# Altium schematic sheet [1]-PWR_12VIN.SchDoc([1]-PWR_12VIN)
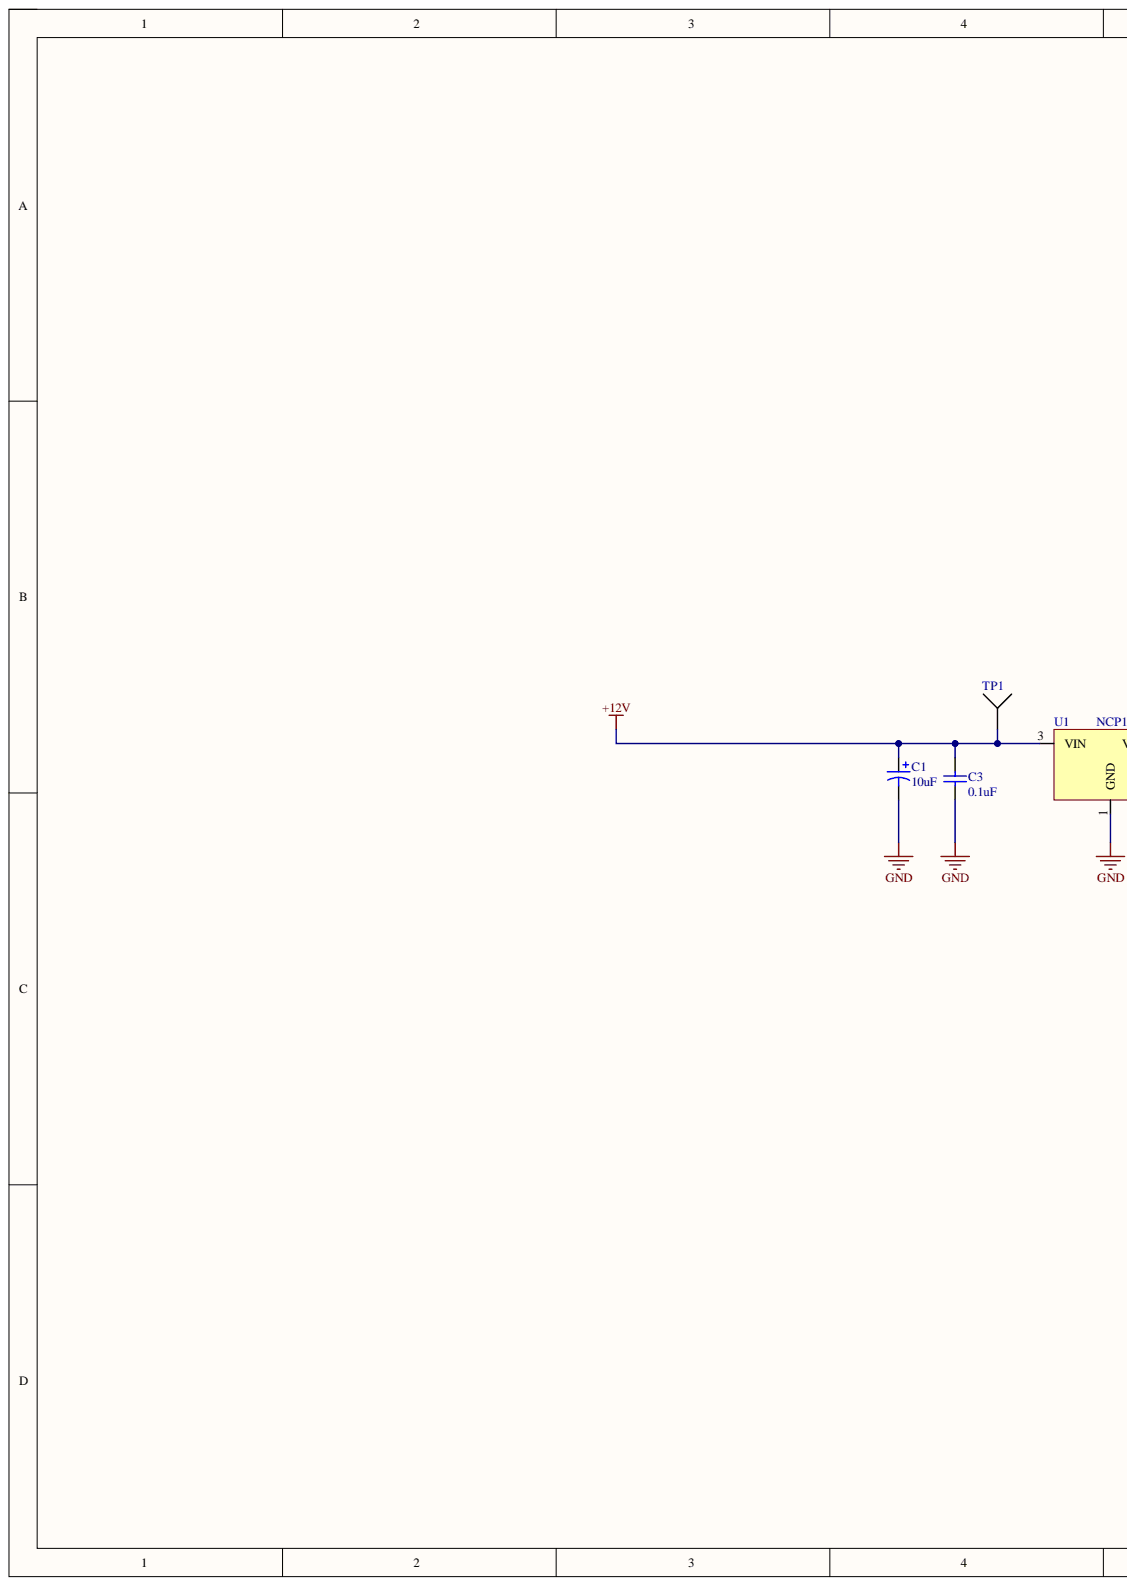
[diagram p0: [1]-PWR_12VIN.SchDoc, left]
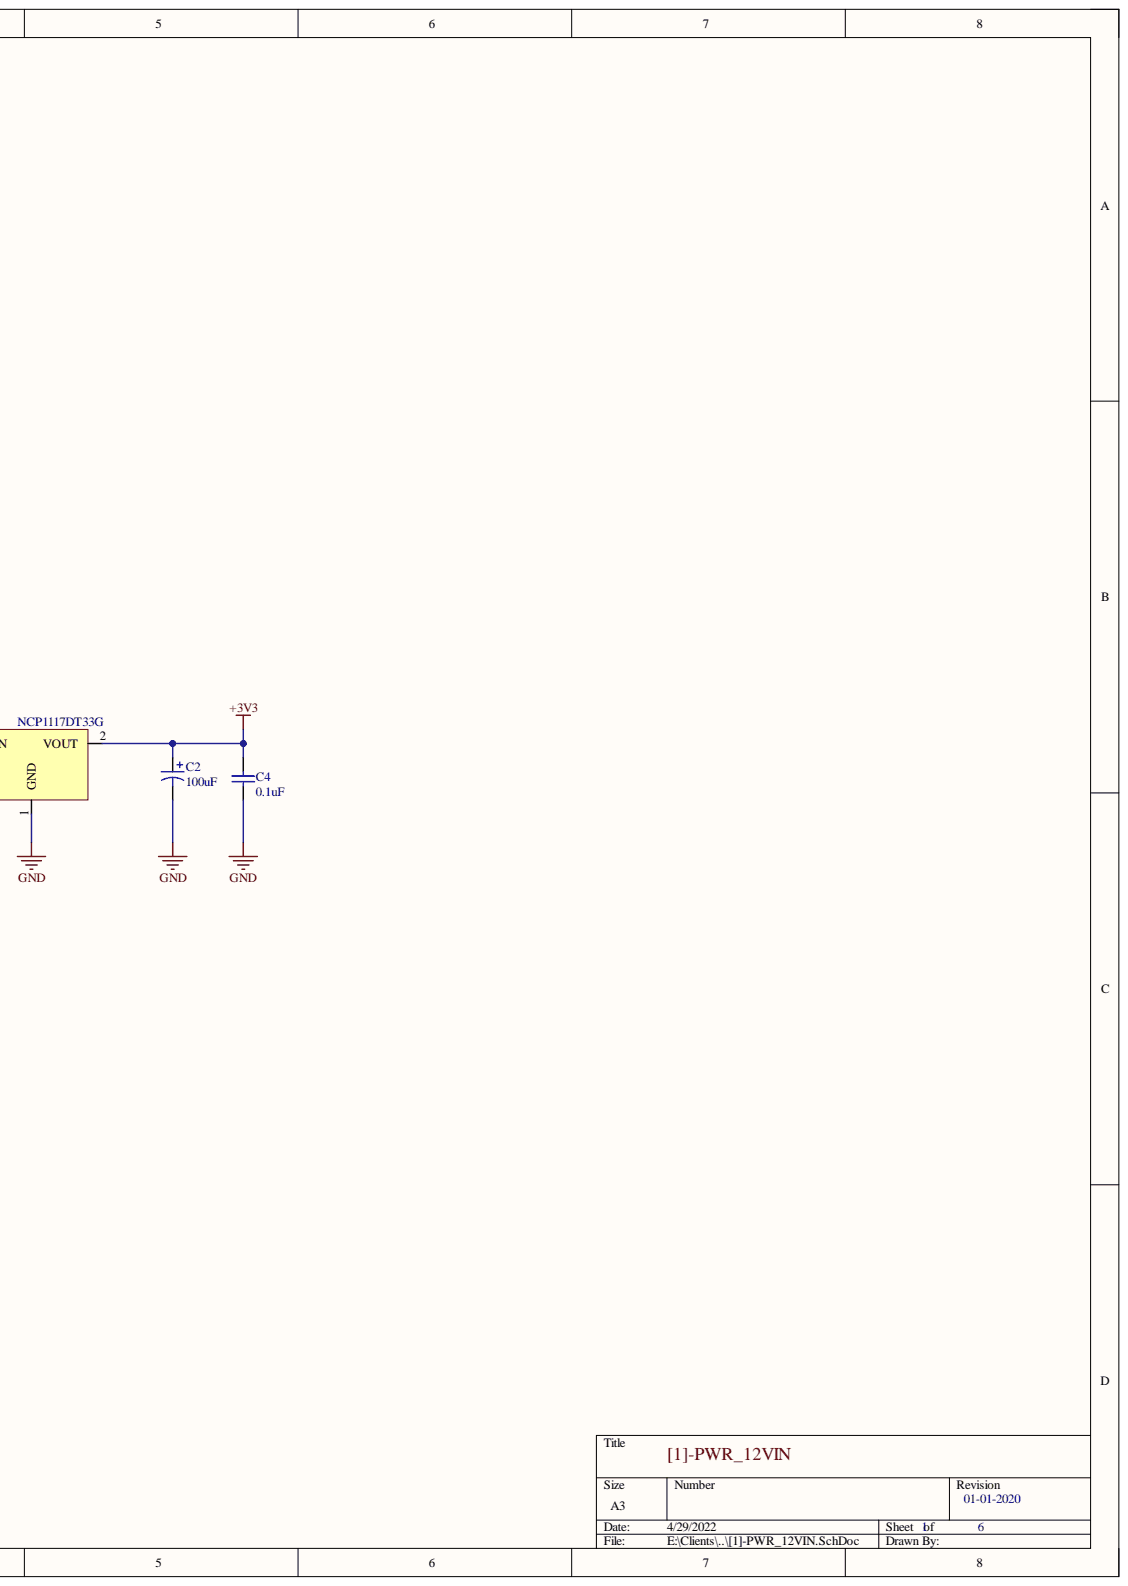
[diagram p1: [1]-PWR_12VIN.SchDoc, right]

## Parts
C1  10uF  [CAP_EEE_C-L]
C2  100uF  [CAPAE6553X55M]
C3  0.1uF  [CAPC1608N]
C4  0.1uF  [CAPC1608N]
TP1  TP_1_MM  [TP_1_MM]  (value not drawn)  (no BOM)
U1  NCP1117DT33G  [DPAK-L]

## Nets
+3V3: C2.1 C4.2 U1.2
+12V: C1.2 C3.2 TP1.1 U1.3
GND: C1.1 C2.2 C3.1 C4.1 U1.1

## Library data of the parts
C1 = EEE-1EA100SR: CAP ALUM 10UF 20% 25V SMD; Manufacturer 1: Panasonic; Lead Length: 2.2mm; Leakage Current: 3uA; Life (Hours): 2000Hour; Ripple Current: 28mA; Capacitance: 10uF; Case/Package: Radial; Component Kind: Standard; Contact Plating: Tin; Diameter: 5mm; Height: 5.4mm; Height - Seated (Max): 0.213inch; Lead Pitch: 1.5mm; Length: 5.5mm; Manufacturer Part Number 1: EEE-1EA100SR; Max Operating Temperature: 85°C; Min Operating Temperature: -40°C; Mount: PCB,Surface Mount; Number of Pins: 2; Package Quantity: 1; Packaging: Tape and Reel; Pricing 1: 1=0.31, 10=0.214, 100=0.1286, 500=0.09646 (USD); Radiation Hardening: No; REACH SVHC: No SVHC; Ripple Current (AC): 28mA; Stock 1: 6724; Supplier 1: Digi-Key; Supplier Part Number 1: PCE3894CT-ND; Termination: SMD/SMT; Tolerance: 20%; Voltage: 25V; Voltage Rating: 25V; Voltage Rating (DC): 25V; Width: 5.3mm; Library Name: bitsnio.SchLib
C2 = EEE-1AA101WR: CAP ALUM 100UF 20% 10V SMD; Manufacturer 1: Panasonic ECG; Description 1: Cap Aluminum Lytic 100uF 10V 20% (5 X 5.4mm) SMD 50mA 1000h 85C Automotive T/R; Life (Hours): 1000Hour; Ripple Current: 50mA; Capacitance: 100uF; Case/Package: Radial; Component Kind: Standard; ComponentLink1Description: https://datasheet.ciiva.com/26945/getdatasheetpartid-169213-26945071.pdf; ComponentLink1URL: https://datasheet.ciiva.com/26945/getdatasheetpartid-169213-26945071.pdf; ComponentLink2Description: https://datasheet.ciiva.com/2983/aba0000ce2-2983906.pdf; ComponentLink2URL: https://datasheet.ciiva.com/2983/aba0000ce2-2983906.pdf; ComponentLink3Description: https://datasheet.ciiva.com/24027/aba0000ce2-40801-24027541.pdf; ComponentLink3URL: https://datasheet.ciiva.com/24027/aba0000ce2-40801-24027541.pdf; ComponentLink4Description: https://datasheet.ciiva.com/30075/11aba0000ce2-30075303.pdf; ComponentLink4URL: https://datasheet.ciiva.com/30075/11aba0000ce2-30075303.pdf; ComponentLink5Description: https://datasheet.ciiva.com/16427/aba0000ce2-16427544.pdf; ComponentLink5URL: https://datasheet.ciiva.com/16427/aba0000ce2-16427544.pdf; ComponentLink6Description: https://datasheet.ciiva.com/14598/70255710-14598233.pdf; ComponentLink6URL: https://datasheet.ciiva.com/14598/70255710-14598233.pdf; ComponentLink7Description: https://datasheet.ciiva.com/14605/70255710-14605689.pdf; ComponentLink7URL: https://datasheet.ciiva.com/14605/70255710-14605689.pdf; ComponentLink8Description: https://datasheet.ciiva.com/11673/aba0000ce2-11673882.pdf; ComponentLink8URL: https://datasheet.ciiva.com/11673/aba0000ce2-11673882.pdf; Contact Plating: Tin; Diameter: 5mm; Height: 5.4mm; Height - Seated (Max): 0.213inch; Lead Free: Lead Free; Length: 5.5mm; Manufacturer Part Number 1: EEE-1AA101WR; Max Operating Temperature: 85°C; Min Operating Temperature: -40°C; Mount: Surface Mount,PCB; Package Quantity: 1; Packaging: Tape and Reel; Pricing 1: 1=0.31, 10=0.212, 100=0.1272, 500=0.0954 (USD); Radiation Hardening: No; REACH SVHC: Unknown; Ripple Current (AC): 50mA; Stock 1: 122621; Supplier 1: Digi-Key; Supplier Part Number 1: PCE3867CT-ND; Termination: SMD/SMT; Tolerance: 20%; Voltage Rating: 10V; Voltage Rating (DC): 10V; Library Name: bitsnio.SchLib
C3 C4 = CC0603KRX7R8BB104: CAP CER 0.1UF 25V X7R 0603; Manufacturer 1: Yageo; Capacitance: 100nF; Tolerance: 10%; Case Code (Imperial): 0603; Case Code (Metric): 1608; Case/Package: 0603; Component Kind: Standard; ComponentLink1Description: https://datasheet.ciiva.com/11786/0900766b80c10176-11786120.pdf; ComponentLink1URL: https://datasheet.ciiva.com/11786/0900766b80c10176-11786120.pdf; ComponentLink2Description: https://datasheet.ciiva.com/144/mlccorderingcode-144075.pdf; ComponentLink2URL: https://datasheet.ciiva.com/144/mlccorderingcode-144075.pdf; ComponentLink3Description: https://datasheet.ciiva.com/17434/upy-gphc-x7r-6-3v-to-50v-8-17434263.pdf; ComponentLink3URL: https://datasheet.ciiva.com/17434/upy-gphc-x7r-6-3v-to-50v-8-17434263.pdf; ComponentLink4Description: https://datasheet.ciiva.com/26829/getdatasheetpartid-403577-26829431.pdf; ComponentLink4URL: https://datasheet.ciiva.com/26829/getdatasheetpartid-403577-26829431.pdf; ComponentLink5Description: https://datasheet.ciiva.com/11587/upy-gphc-x7r-6-3v-to-50v-8-11587208.pdf; ComponentLink5URL: https://datasheet.ciiva.com/11587/upy-gphc-x7r-6-3v-to-50v-8-11587208.pdf; ComponentLink6Description: https://datasheet.ciiva.com/13389/upy-gphc-x7r-6-3v-to-50v-8-13389352.pdf; ComponentLink6URL: https://datasheet.ciiva.com/13389/upy-gphc-x7r-6-3v-to-50v-8-13389352.pdf; ComponentLink7Description: https://datasheet.ciiva.com/28450/1489800-28450584.pdf; ComponentLink7URL: https://datasheet.ciiva.com/28450/1489800-28450584.pdf; ComponentLink8Description: https://datasheet.ciiva.com/144/mlccorderingcode-144073.pdf; ComponentLink8URL: https://datasheet.ciiva.com/144/mlccorderingcode-144073.pdf; ComponentLink9Description: https://datasheet.ciiva.com/144/mlccorderingcode-144074.pdf; ComponentLink9URL: https://datasheet.ciiva.com/144/mlccorderingcode-144074.pdf; ComponentLink10Description: https://datasheet.ciiva.com/7978/1489800-7978155.pdf; ComponentLink10URL: https://datasheet.ciiva.com/7978/1489800-7978155.pdf; Composition: Ceramic; Depth: 0.8mm; Dielectric: X7R; Halogen Free: Halogen Free; Height: 0.8mm; Lead Free: Lead Free; Length: 1.6mm; Manufacturer Part Number 1: CC0603KRX7R8BB104; Max Operating Temperature: 125°C; Min Operating Temperature: -55°C; Mount: Surface Mount; Number of Pins: 2; Package Quantity: 1; Packaging: Tape and Reel; Pricing 1: 1=0.1, 10=0.074, 100=0.0329, 500=0.02352, 1000=0.01848 (USD); Radiation Hardening: No; REACH SVHC: Unknown; Stock 1: 40000; Supplier 1: Digi-Key; Supplier Part Number 1: 311-1341-1-ND; Termination: SMD/SMT; Thickness: 0.035inch; Voltage: 25V; Voltage Rating: 25V; Voltage Rating (DC): 25V; Width: 0.8mm; Library Name: bitsnio.SchLib
U1 = IC_NCP1117DT33G: IC REG LDO 3.3V 1A DPAK; Manufacturer 1: ON Semiconductor; Accuracy: 1%; Dropout Voltage: 1.07V; Input Bias Current: 6mA; Max Input Voltage: 20V; Number of Outputs: 1; Output Type: Fixed; Polarity: Positive; Case/Package: DPAK; Component Kind: Standard; Contact Plating: Matte,Tin; Halogen Free: Halogen Free; Height: 2.38mm; Lead Free: Lead Free; Length: 6.73mm; Manufacturer Part Number 1: NCP1117DT33G; Max Operating Temperature: 125°C; Max Output Current: 1A; Min Current Limit: 1A; Min Operating Temperature: 0°C; Min Output Voltage: 3.3V; Mount: Surface Mount; Nominal Output Voltage: 3.3V; Number of Pins: 3; Number of Regulators: 1; Output Current: 1A; Output Voltage: 3.3V; Output Voltage Accuracy: 1%; Package Quantity: 75; Packaging: Rail/Tube; Pricing 1: 1=0.44, 75=0.3504, 150=0.28027, 525=0.22023, 1050=0.17017 (USD); Quiescent Current: 6mA; Radiation Hardening: No; REACH SVHC: No SVHC; Stock 1: 3065; Supplier 1: Digi-Key; Supplier Part Number 1: NCP1117DT33GOS-ND; Width: 6.22mm; Library Name: bitsnio.SchLib
TP1 = TP_1_MM: Library Name: bitsnio.SchLib
